# Altium schematic sheet 1-MCU.SchDoc(1-MCU)
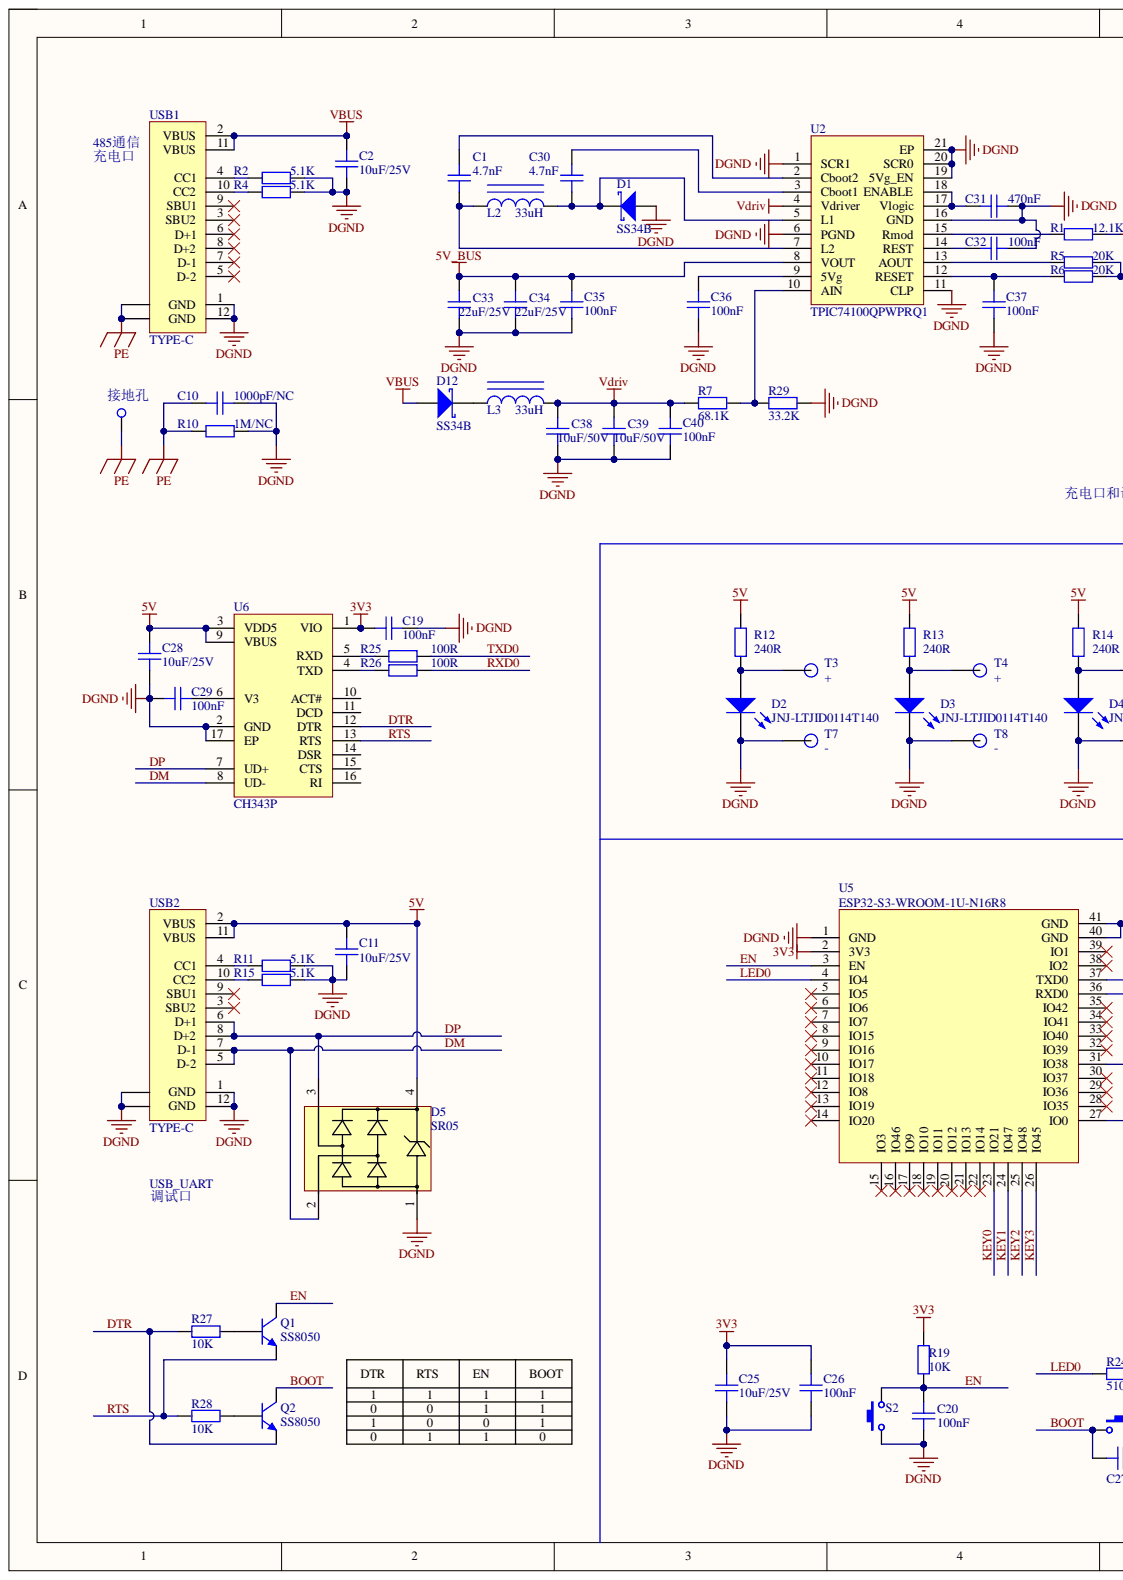
[diagram p0: 1-MCU.SchDoc, left]
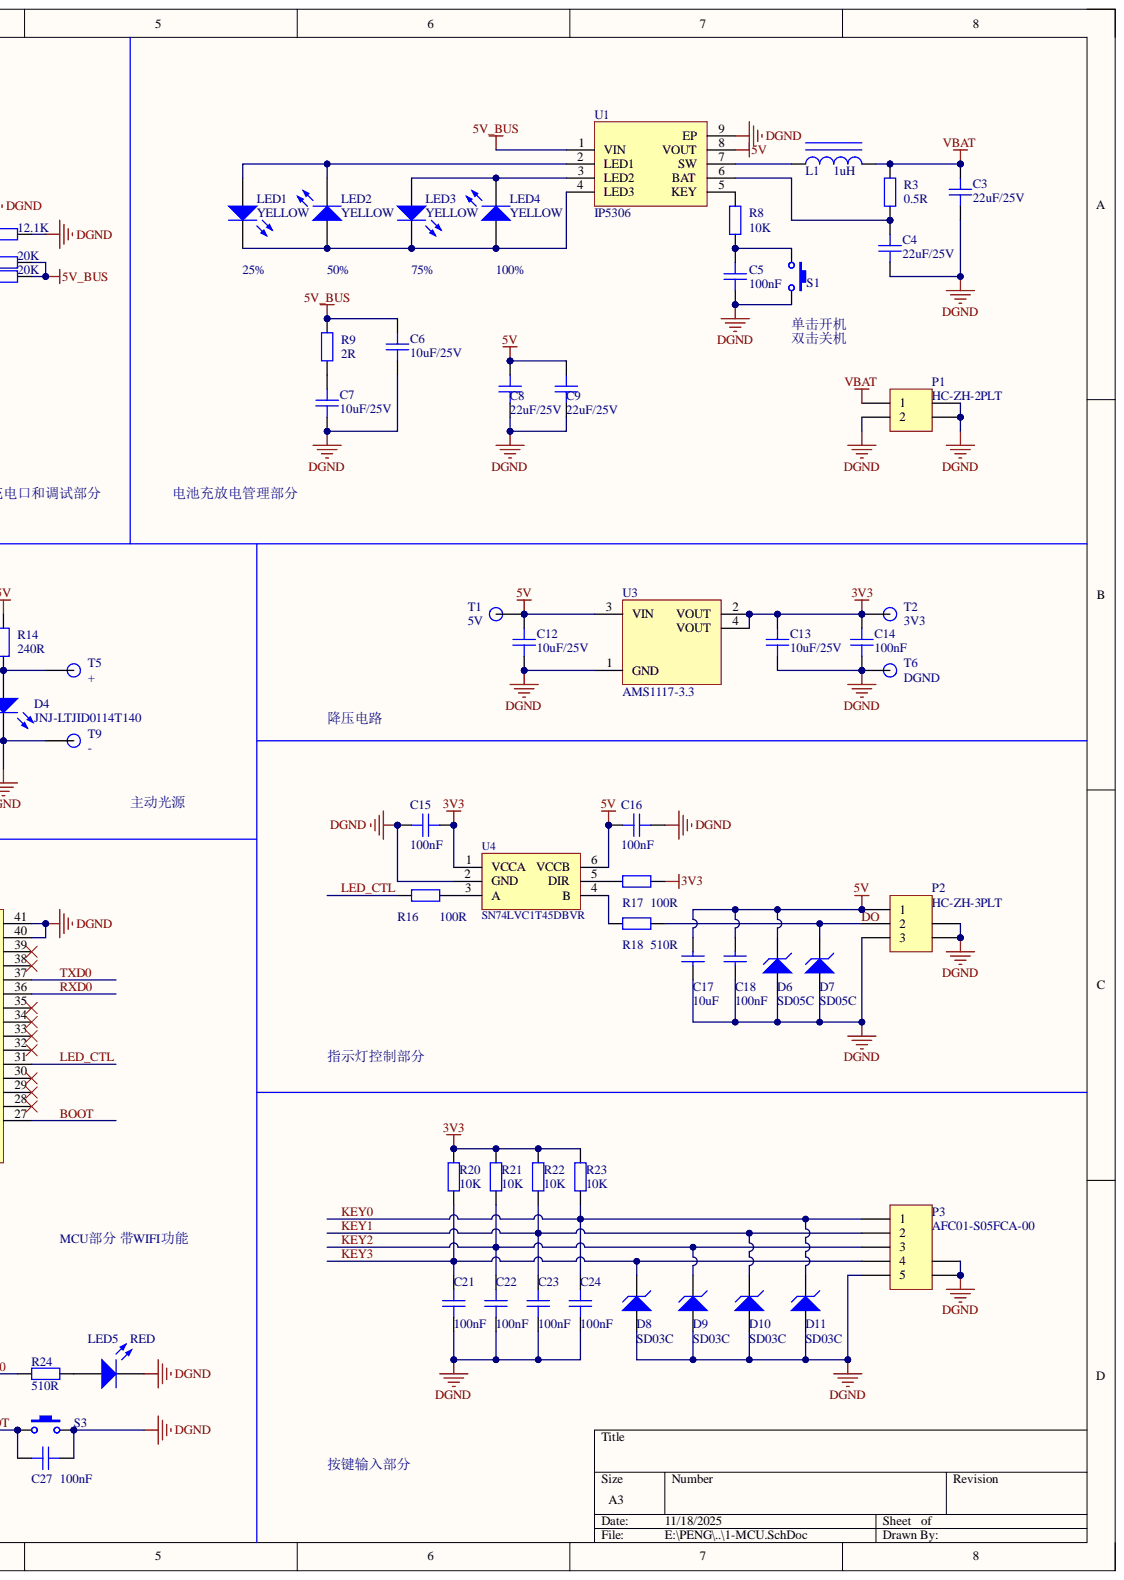
[diagram p1: 1-MCU.SchDoc, right]

## Parts
C1  4.7nF  [0603_C]
C2  10uF/25V  [0805_C]
C3  22uF/25V  [0805_C]
C4  22uF/25V  [0805_C]
C5  100nF  [0603_C]
C6  10uF/25V  [0805_C]
C7  10uF/25V  [0805_C]
C8  22uF/25V  [0805_C]
C9  22uF/25V  [0805_C]
C10  1000pF/NC  [1206_C]
C11  10uF/25V  [0805_C]
C12  10uF/25V  [0805_C]
C13  10uF/25V  [0805_C]
C14  100nF  [0603_C]
C15  100nF  [0603_C]
C16  100nF  [0603_C]
C17  10uF  [0805_C]
C18  100nF  [0603_C]
C19  100nF  [0603_C]
C20  100nF  [0603_C]
C21  100nF  [0603_C]
C22  100nF  [0603_C]
C23  100nF  [0603_C]
C24  100nF  [0603_C]
C25  10uF/25V  [0805_C]
C26  100nF  [0603_C]
C27  100nF  [0603_C]
C28  10uF/25V  [0805_C]
C29  100nF  [0603_C]
C30  4.7nF  [0603_C]
C31  470nF  [0603_C]
C32  100nF  [0603_C]
C33  22uF/25V  [0805_C]
C34  22uF/25V  [0805_C]
C35  100nF  [0603_C]
C36  100nF  [0603_C]
C37  100nF  [0603_C]
C38  10uF/50V  [0805_C]
C39  10uF/50V  [0805_C]
C40  100nF  [0603_C]
D1  SS34B  [SMB_L4.6-W3.6-LS5.4-RD]
D2  JNJ-LTJID0114T140  [LED_ME]
D3  JNJ-LTJID0114T140  [LED_ME]
D4  JNJ-LTJID0114T140  [LED_ME]
D5  SR05  [SOT-143_L2.9-W1.3-P1.92-LS2.3-BR]
D6  SD05C  [SOD-323_L1.8-W1.3-LS2.5-BI]
D7  SD05C  [SOD-323_L1.8-W1.3-LS2.5-BI]
D8  SD03C  [SOD-323_L1.8-W1.3-LS2.5-BI]
D9  SD03C  [SOD-323_L1.8-W1.3-LS2.5-BI]
D10  SD03C  [SOD-323_L1.8-W1.3-LS2.5-BI]
D11  SD03C  [SOD-323_L1.8-W1.3-LS2.5-BI]
D12  SS34B  [SMB_L4.6-W3.6-LS5.4-RD]
ID1  GND  [M2.5]  (value not drawn)
L1  1uH  [IND-SMD_L7.0-W6.6_FXL0630]
L2  33uH  [IND-SMD_L7.0-W6.6_FXL0630]
L3  33uH  [IND-SMD_L7.0-W6.6_FXL0630]
LED1  YELLOW  [LED-SMD_L3.0-W1.5_MHS110WDT-C1]
LED2  YELLOW  [LED-SMD_L3.0-W1.5_MHS110WDT-C1]
LED3  YELLOW  [LED-SMD_L3.0-W1.5_MHS110WDT-C1]
LED4  YELLOW  [LED-SMD_L3.0-W1.5_MHS110WDT-C1]
LED5  RED  [LED0603]
P1  HC-ZH-2PLT  [CONN-SMD_2P-P1.5_HCTL_HC-ZH-2PLT]
P2  HC-ZH-3PLT  [CONN-SMD_3P-P1.5_HCTL_HC-ZH-3PLT]
P3  AFC01-S05FCA-00  [FPC-SMD_AFC01-S05FCA-00]
Q1  SS8050  [SOT-23-3_L2.9-W1.3-P0.95-LS2.4-BR]
Q2  SS8050  [SOT-23-3_L2.9-W1.3-P0.95-LS2.4-BR]
R1  12.1K  [0603_R]
R2  5.1K  [0603_R]
R3  0.5R  [0805_R]
R4  5.1K  [0603_R]
R5  20K  [0603_R]
R6  20K  [0603_R]
R7  68.1K  [0603_R]
R8  10K  [0603_R]
R9  2R  [0805_R]
R10  1M/NC  [1206_R]
R11  5.1K  [0603_R]
R12  240R  [0805_R]
R13  240R  [0805_R]
R14  240R  [0805_R]
R15  5.1K  [0603_R]
R16  100R  [0603_R]
R17  100R  [0603_R]
R18  510R  [0603_R]
R19  10K  [0603_R]
R20  10K  [0603_R]
R21  10K  [0603_R]
R22  10K  [0603_R]
R23  10K  [0603_R]
R24  510R  [0603_R]
R25  100R  [0603_R]
R26  100R  [0603_R]
R27  10K  [0603_R]
R28  10K  [0603_R]
R29  33.2K  [0603_R]
S1  TS24CA  [SW-SMD_L4.7-W3.5-P3.35-EH]  (value not drawn)
S2  TS-1088-AR02016  [SW-SMD_L3.9-W3.0-P4.45]  (value not drawn)
S3  TS-1088-AR02016  [SW-SMD_L3.9-W3.0-P4.45]  (value not drawn)
T1  5V  [TEST_P2]
T2  3V3  [TEST_P2]
T3  +  [TEST_P2]
T4  +  [TEST_P2]
T5  +  [TEST_P2]
T6  DGND  [TEST_P2]
T7  -  [TEST_P2]
T8  -  [TEST_P2]
T9  -  [TEST_P2]
U1  IP5306  [ESOP-8_L4.9-W3.9-P1.27-LS6.0-BL-EP]
U2  TPIC74100QPWPRQ1  [HTSSOP-20_L6.5-W4.4-P0.65-LS6.4-BL-EP]
U3  AMS1117-3.3  [SOT-223-3_L6.5-W3.4-P2.30-LS7.0-BR]
U4  SN74LVC1T45DBVR  [SOT-23-6_L2.9-W1.6-P0.95-LS2.8-BR]
U5  ESP32-S3-WROOM-1U-N16R8  [WIRELM-SMD_ESP32-S3-WROOM-1U]
U6  CH343P  [TQFN-16_L3.0-W3.0-P0.50-BL-EP1.7]
USB1  TYPE-C  [USB-C-SMD_TYPE-C-6PIN-2MD-073]
USB2  TYPE-C  [USB-C-SMD_TYPE-C-6PIN-2MD-073]

## Nets
3V3: C13.2 C14.2 C15.1 C19.1 C25.2 C26.2 R17.1 R19.1 R20.2 R21.2 R22.2 R23.2 T2.1 U3.2(VOUT) U3.4(VOUT) U4.1(VCCA) U5.2(3V3) U6.1(VIO)
5V: C8.2 C9.2 C11.2 C12.2 C16.2 C17.2 C18.2 C28.1 D5.4 D6.2 P2.1 R12.2 R13.2 R14.2 T1.1 U1.8(VOUT) U3.3(VIN) U4.6(VCCB) U6.3(VDD5) U6.9(VBUS) USB2.2(VBUS) USB2.11(VBUS)
5V_BUS: C6.2 C33.2 C34.2 C35.1 R5.1 R6.1 R9.1 U1.1(VIN) U2.8(VOUT)
DGND: C2.1 C3.1 C4.1 C5.1 C6.1 C7.1 C8.1 C9.1 C10.2 C11.1 C12.1 C13.1 C14.1 C15.2 C16.1 C17.1 C18.1 C19.2 C20.1 C21.1 C22.1 C23.1 C24.1 C25.1 C26.1 C27.1 C28.2 C29.1 C31.2 C32.2 C33.1 C34.1 C35.2 C36.2 C37.2 C38.1 C39.1 C40.2 D1.2 D2.2 D3.2 D4.2 D5.1 D6.1 D7.1 D8.1 D9.1 D10.1 D11.1 LED5.1 P1.2 P1.3 P1.4 P2.3 P2.4 P2.5 P3.5 P3.6 P3.7 R1.1 R2.1 R4.1 R10.2 R11.1 R15.1 R29.2 S1.2 S2.1 S3.2 T6.1 T7.1 T8.1 T9.1 U1.9(EP) U2.1(SCR1) U2.6(PGND) U2.11(CLP) U2.16(GND) U2.19(5Vg_EN) U2.20(SCR0) U2.21(EP) U3.1(GND) U4.2(GND) U5.1(GND) U5.40(GND) U5.41(GND) U6.2(GND) U6.17(EP) USB1.1(GND) USB1.12(GND) USB2.1(GND) USB2.12(GND) USB2.13 USB2.14
PE: C10.1 ID1.1 R10.1 USB1.13 USB1.14
VBAT: C3.2 L1.2 P1.1 R3.1
VBUS: C2.2 D12.2 USB1.2(VBUS) USB1.11(VBUS)
Vdriv: C38.2 C39.2 C40.1 L3.2 R7.1 U2.4(Vdriver)
BOOT: C27.2 Q2.3 S3.1 U5.27(IO0)
DM: D5.2 U6.8(UD-) USB2.5(D-2) USB2.7(D-1)
DO: D7.2 P2.2 R18.1
DP: D5.3 U6.7(UD+) USB2.6(D+1) USB2.8(D+2)
DTR: Q2.2 R27.1 U6.12(DTR)
EN: C20.2 Q1.3 R19.2 S2.2 U5.3(EN)
KEY0: C24.2 D11.2 P3.1 R23.1 U5.23(IO21)
KEY1: C23.2 D10.2 P3.2 R22.1 U5.24(IO47)
KEY2: C22.2 D9.2 P3.3 R21.1 U5.25(IO48)
KEY3: C21.2 D8.2 P3.4 R20.1 U5.26(IO45)
LED0: R24.2 U5.4(IO4)
LED_CTL: R16.2 U5.31(IO38)
RTS: Q1.2 R28.1 U6.13(RTS)
RXD0: R26.1 U5.36(RXD0)
TXD0: R25.1 U5.37(TXD0)
NetC1_1: C1.1 L2.1 U2.7(L2)
NetC1_2: C1.2 U2.2(Cboot2)
NetC4_2: C4.2 R3.2 U1.6(BAT)
NetC5_2: C5.2 R8.1 S1.1
NetC7_2: C7.2 R9.2
NetC29_2: C29.2 U6.6(V3)
NetC30_1: C30.1 D1.1 L2.2 U2.5(L1)
NetC30_2: C30.2 U2.3(Cboot1)
NetC31_1: C31.1 U2.17(Vlogic) U2.18(ENABLE)
NetC32_1: C32.1 U2.14(REST)
NetC36_1: C36.1 U2.9(5Vg)
NetC37_1: C37.1 R6.2 U2.12(RESET)
NetD2_1: D2.1 R12.1 T3.1
NetD3_1: D3.1 R13.1 T4.1
NetD4_1: D4.1 R14.1 T5.1
NetD12_1: D12.1 L3.1
NetL1_1: L1.1 U1.7(SW)
NetLED1_1: LED1.1 LED2.2 LED3.1 LED4.2 U1.4(LED3)
NetLED1_2: LED1.2 LED2.1 U1.2(LED1)
NetLED3_2: LED3.2 LED4.1 U1.3(LED2)
NetLED5_2: LED5.2 R24.1
NetQ1_1: Q1.1 R27.2
NetQ2_1: Q2.1 R28.2
NetR1_2: R1.2 U2.15(Rmod)
NetR2_2: R2.2 USB1.4(CC1)
NetR4_2: R4.2 USB1.10(CC2)
NetR5_2: R5.2 U2.13(AOUT)
NetR7_2: R7.2 R29.1 U2.10(AIN)
NetR8_2: R8.2 U1.5(KEY)
NetR11_2: R11.2 USB2.4(CC1)
NetR15_2: R15.2 USB2.10(CC2)
NetR16_1: R16.1 U4.3(A)
NetR17_2: R17.2 U4.5(DIR)
NetR18_2: R18.2 U4.4(B)
NetR25_2: R25.2 U6.5(RXD)
NetR26_2: R26.2 U6.4(TXD)

Not connected: U5 5(IO5) 6(IO6) 7(IO7) 8(IO15) 9(IO16) 10(IO17) 11(IO18) 12(IO8) 13(IO19) 14(IO20) 15(IO3) 16(IO46) 17(IO9) 18(IO10) 19(IO11) 20(IO12) 21(IO13) 22(IO14) 28(IO35) 29(IO36) 30(IO37) 32(IO39) 33(IO40) 34(IO41) 35(IO42) 38(IO2) 39(IO1); U6 10(ACT#) 11(DCD) 14(DSR) 15(CTS) 16(RI); USB1 3(SBU2) 5(D-2) 6(D+1) 7(D-1) 8(D+2) 9(SBU1); USB2 3(SBU2) 9(SBU1)

## Library data of the parts
C1 C5 C15 C16 C19 C20 C29-C32 C35-C37 C40 = CAP 0603: Component Kind: Standard; Supplier: LCSC; Library Name: PENG-20250225-2.SCHLIB
  C1 C30: Supplier Part: C106218; Value: 4.7nF
  C5 C15 C16 C20: Supplier Footprint: 0603; Supplier Part: C14663; Value: 100nF
  C19 C29 C32 C35-C37 C40: Supplier Part: C14663; Value: 100nF
  C31: Supplier Part: C513577; Value: 470nF
C2-C4 C6-C9 C11-C14 C21-C28 C33 C34 C38 C39 = Cap: Capacitor; Component Kind: Standard; Supplier: LCSC
  C2 C6 C7 C11-C13 C25 C28: Supplier Part: C89831; Value: 10uF/25V
  C3 C4 C8 C9 C33 C34: Supplier Part: C784585; Value: 22uF/25V
  C14 C21-C24 C26 C27: Supplier Part: C14663; Value: 100nF
  C38 C39: Supplier Part: C2932476; Value: 10uF/50V
  C2-C4 C6-C9 C11-C14 C25-C28 C33 C34 C38 C39: Library Name: PCBA-PRE10-001 V1.0.0.SCHLIB
  C21-C24: Library Name: PCBA-PRE10-04 V1.0.0.SCHLIB
C10 = Cap 1206: Capacitor; Component Kind: Standard; Supplier: LCSC; Supplier Footprint: 1206; Value: 1000pF/NC; Library Name: PCBA-PRE10-001 V1.0.0.SCHLIB
C17 = 0805 10uF (106) 10% 25V: 贴片电容; Component Kind: Standard; ComponentLink1Description: 供应商链接; ComponentLink1URL: http://www.szlcsc.com/product/details_16532.html; Library Name: Miscellaneous Devices LC.IntLib; Package: 0805; Supplier: LC; Supplier Part: C15850
C18 = 0603 100nF (104) 10% 50V: 贴片电容; Component Kind: Standard; ComponentLink1Description: 供应商链接; ComponentLink1URL: http://www.szlcsc.com/product/details_15331.html; Library Name: Miscellaneous Devices LC.IntLib; Package: 0603; Supplier: LC; Supplier Part: C14663
D1 D12 = Diode Schottky: Schottky Diode; Component Kind: Standard; Supplier: LCSC; Supplier Part: C7420366; Value: SS34B; Library Name: PENG-20250520.SCHLIB
D2-D4 = LED0: Typical INFRARED GaAs LED; Component Kind: Standard; Library Name: Miscellaneous Devices.IntLib; Simulation: LED0; Supplier: LCSC; Supplier Part: C19185835
D5 = SR05_C521962: Manufacturer: TECH PUBLIC(台舟); Add into BOM: yes; Clamping Voltage: 25V; level of protection: IEC 61000-4-2; Number of Channels: 三路; Peak Pulse Current (Ipp): 6A; Peak Pulse Power Dissipation (Ppp): 150W; Polarity: 单向; Reverse Leakage Current (Ir): 100nA; Reverse Stand-Off Voltage (Vrwm): 5V; Type: ESD; Voltage - Breakdown: 6V; Component Kind: Standard; Convert to PCB: yes; Datasheet: https://atta.szlcsc.com/upload/public/pdf/source/20210810/DE8C9F15154892909ECFEE94FF023C89.pdf; Device: SR05_C521962; JLCPCB Part Class: Extended Part; LCSC Part Name: SR05; Manufacturer Part: SR05; Operating Temperature: -55℃~+125℃; Supplier: LCSC; Supplier Footprint: SOT-143-4; Supplier Part: C521962; Symbol: SR05_C521962; Library Name: PCBA-PRE10-001 V1.0.0.SCHLIB
D6-D11 = Diode Zener: Zener Diode; Component Kind: Standard; Supplier: LCSC; Library Name: PCBA-PRE10-001 V1.0.0.SCHLIB
  D6 D7: Supplier Part: C907858; Value: SD05C
  D8-D11: Supplier Part: C502532; Value: SD03C
ID1 = ID: PCB Mark; Component Kind: Standard; Library Name: PCBA-PRE10-001 V1.0.0.SCHLIB
L1-L3 = Inductor Iron: Magnetic-Core Inductor; Component Kind: Standard; Supplier: LCSC; Library Name: PCBA-PRE10-001 V1.0.0.SCHLIB
  L1: Supplier Part: C167216; Value: 1uH
  L2 L3: Supplier Part: C177245; Value: 33uH
LED1-LED4 = LED 0603 YELLOW: Light Emitting Diode; Component Kind: Standard; Supplier: LCSC; Supplier Part: C3028827; Library Name: PCBA-PRE10-04 V1.0.0.SCHLIB
LED5 = LED 0603 RED: Manufacturer: 国星光电; Manufacturer Part: NCD0603R1; Add into BOM: yes; Device: NCD0603R1_C84263; Diode Configuration: 独立二极管; Dominant Wavelength: 615nm~630nm; Emitted Color: 红色; Forward Current: 20mA; Forward Voltage (VF): 1.6V~2.6V; Lens Color: 无色透明透镜; Luminous Intensity: 80mcd~220mcd; Power Dissipation: 65mW; Symbol: FC-DA1608HRK-620D; Viewing Angle: 130°; Color Temperature: -; Component Kind: Standard; Convert to PCB: yes; Datasheet: https://atta.szlcsc.com/upload/public/pdf/source/20200820/C84263_DABC6A75E58F576973B407FDBA283D60.pdf; JLCPCB Part Class: Extended Part; Lamp Holder Type: -; LCSC Part Name: NCD0603R1; Mounting Sytle: -; Operating Temperature: -30℃~+85℃; PCB3D: LED0603-RD_RED; Peak Wavelength: -; Supplier: LCSC; Supplier Footprint: 0603; Supplier Part: C84263; Library Name: PENG-20250225-2.SCHLIB
P1 = CON 2P: Connector; Component Kind: Standard; Supplier: LCSC; Supplier Part: C2845433; Value: HC-ZH-2PLT; Library Name: PCBA-PRE10-04 V1.0.0.SCHLIB
P2 = CON 3P: Connector; Component Kind: Standard; Supplier: LCSC; Supplier Part: C2845434; Value: HC-ZH-3PLT; Library Name: PCBA-PRE10-04 V1.0.0.SCHLIB
P3 = CON 5P: Connector; Component Kind: Standard; Supplier: LCSC; Supplier Part: C262654; Value: AFC01-S05FCA-00; Library Name: PCBA-PRE10-04 V1.0.0.SCHLIB
Q1 Q2 = NPN: NPN Bipolar Transistor; Component Kind: Standard; Supplier: LCSC; Supplier Part: C2150; Value: SS8050; Library Name: PCBA-PRE10-04 V1.0.0.SCHLIB
R1-R7 R9 R11 R15-R18 R25 R26 R29 = Res: Resistor; Component Kind: Standard; Supplier: LCSC; Library Name: PCBA-PRE10-001 V1.0.0.SCHLIB
  R1: Supplier Part: C123417; Value: 12.1K
  R2 R4 R11 R15: Supplier Part: C105580; Value: 5.1K
  R3: Supplier Part: C327217; Value: 0.5R
  R5 R6: Supplier Part: C105575; Value: 20K
  R7: Supplier Part: C25976; Value: 68.1K
  R9: Supplier Part: C114246; Value: 2R
  R16 R17 R25 R26: Supplier Part: C105588; Value: 100R
  R18: Supplier Part: C113299; Value: 510R
  R29: Supplier Part: C23003; Value: 33.2K
R8 R19-R24 R27 R28 = RES 0603: Component Kind: Standard; Supplier: LCSC; Library Name: PENG-20250225-2.SCHLIB
  R8 R19-R23 R27 R28: Supplier Footprint: 0603; Supplier Part: C98220; Value: 10K
  R24: Supplier Part: C114670; Value: 510R
R10 = Res 1206: Resistor; Component Kind: Standard; Supplier: LCSC; Value: 1M/NC; Library Name: PCBA-PRE10-001 V1.0.0.SCHLIB
R12-R14 = 0805 240R: 贴片电阻; Component Kind: Standard; Supplier: LCSC; Supplier Part: C185292; Library Name: PCBA-JT004-011 V1.1.0.SCHLIB
S1-S3 = SW-PB: Switch; Component Kind: Standard; Supplier: LCSC; Library Name: PCBA-PRE10-001 V1.0.0.SCHLIB
  S1: Supplier Part: C5373430; Value: TS24CA
  S2 S3: PackageDescription: Switch; 2 Leads; Supplier Part: C720477; Value: TS-1088-AR02016
T1-T9 = TEST_P: Test Ponit; Component Kind: Standard; Library Name: PCBA-PRE10-001 V1.0.0.SCHLIB
  T1: Value: 5V
  T2: Value: 3V3
  T3-T5: Value: +
  T6: Value: DGND
  T7-T9: Value: -
U1 = IP5306: Manufacturer: INJOINIC(英集芯); Add into BOM: yes; Component Kind: Standard; Convert to PCB: yes; Datasheet: https://atta.szlcsc.com/upload/public/pdf/source/20190627/C384572_9925B3DDC4041BC6108E5AB46A2A0AB1.pdf; Device: IP5306; JLCPCB Part Class: Extended Part; LCSC Part Name: IP5306; Manufacturer Part: IP5306; Supplier: LCSC; Supplier Footprint: ESOP-8; Supplier Part: C181692; Symbol: IP5306; Library Name: PCBA-PRE10-04 V1.0.0.SCHLIB
U3 = AMS1117-3.3_C6186: Manufacturer: AMS; Add into BOM: yes; Number of Outputs: 1; Output Configuration: 正极; Output Current: 1A; Output Type: 固定; Output Voltage: 3.3V; Power Supply Rejection Ratio (PSRR): 72dB@(120Hz); Voltage Dropout: 1.3V@(800mA); Component Kind: Standard; Convert to PCB: yes; Datasheet: https://atta.szlcsc.com/upload/public/pdf/source/20180727/C6186_7A095716F0823C02F1997A905E26C086.pdf; Device: AMS1117-3.3_C6186; Features: 过流保护(OCP)；热关断(TSD); JLCPCB Part Class: Basic Part; LCSC Part Name: 输出3.3V 1A; Manufacturer Part: AMS1117-3.3; Noise: -; Operating Temperature: -; standby current: -; Supplier: LCSC; Supplier Footprint: SOT-223; Supplier Part: C6186; Symbol: AMS1117-3.3; Voltage - Supply: -; Library Name: PENG-20250310.SCHLIB
U4 = SN74LVC1T45DBVR: Manufacturer: TI(德州仪器); Add into BOM: yes; Channel Type: 双向; Channels per Circuit: 1; Data Rate: 420Mbps; Output Type: 非反相；三态; Supply Voltage (VCCA): 1.65V~5.5V; Component Kind: Standard; Convert to PCB: yes; Datasheet: https://www.ti.com/cn/lit/gpn/sn74lvc1t45; Device: SN74LVC1T45DBVR; JLCPCB Part Class: Extended Part; LCSC Part Name: SN74LVC1T45DBVR; Manufacturer Part: SN74LVC1T45DBVR; Name: SN74LVC1T45DBVR; Number of Circuits: 1; Operating Temperature: -40℃~+85℃; PCB3D: SOT-23-6_L2.9-W1.6-H1.5-LS2.8-P0.95; Supplier: LCSC; Supplier Footprint: SOT-23-6; Supplier Part: C7843; Supply Voltage (VCCB): 1.65V~5.5V; Symbol: SN74LVC1T45DBVR; Library Name: PCBA-PRE10-04 V1.0.0.SCHLIB
U5 = ESP32-S3-WROOM-1U-N16R8: Manufacturer: ESPRESSIF(乐鑫); Add into BOM: yes; Component Kind: Standard; Convert to PCB: yes; Datasheet: https://atta.szlcsc.com/upload/public/pdf/source/20240130/9D71F4DF55874C504AB629FEEE3F038E.pdf; Device: ESP32-S3-WROOM-1U-N16R8; JLCPCB Part Class: Extended Part; LCSC Part Name: ESP32-S3-WROOM-1U-N16R8; Manufacturer Part: ESP32-S3-WROOM-1U-N16R8; Supplier: LCSC; Supplier Footprint: SMD,18x19.2mm; Supplier Part: C3013946; Symbol: ESP32-S3-WROOM-1U-N16R8; Library Name: PCBA-PRE10-04 V1.0.0.SCHLIB
U6 = CH343P: Manufacturer: WCH(南京沁恒); Add into BOM: yes; Applications Function: -; Component Kind: Standard; Convert to PCB: yes; Datasheet: https://atta.szlcsc.com/upload/public/pdf/source/20211010/C2846043_7FA705E1F14A2906F6A2AFA45FA28209.pdf; Device: CH343P; JLCPCB Part Class: Extended Part; LCSC Part Name: CH343P; Manufacturer Part: CH343P; Protocol: -; Supplier: LCSC; Supplier Footprint: TQFN-16-EP(3x3); Supplier Part: C2846043; Symbol: CH343P; Library Name: PCBA-PRE10-04 V1.0.0.SCHLIB
USB1 USB2 = USB TYPE-C: USB; Component Kind: Standard; Supplier: LCSC; Supplier Part: C2765186; Value: TYPE-C; Library Name: PCBA-PRE10-001 V1.0.0.SCHLIB
U2 = TPIC74100QPWPRQ1: Component Kind: Standard; Supplier Part: C81944; Symbol: TPIC74100QPWPRQ1; Library Name: PENG-20250520.SCHLIB
